# Altium schematic sheet TopLevel.SchDoc
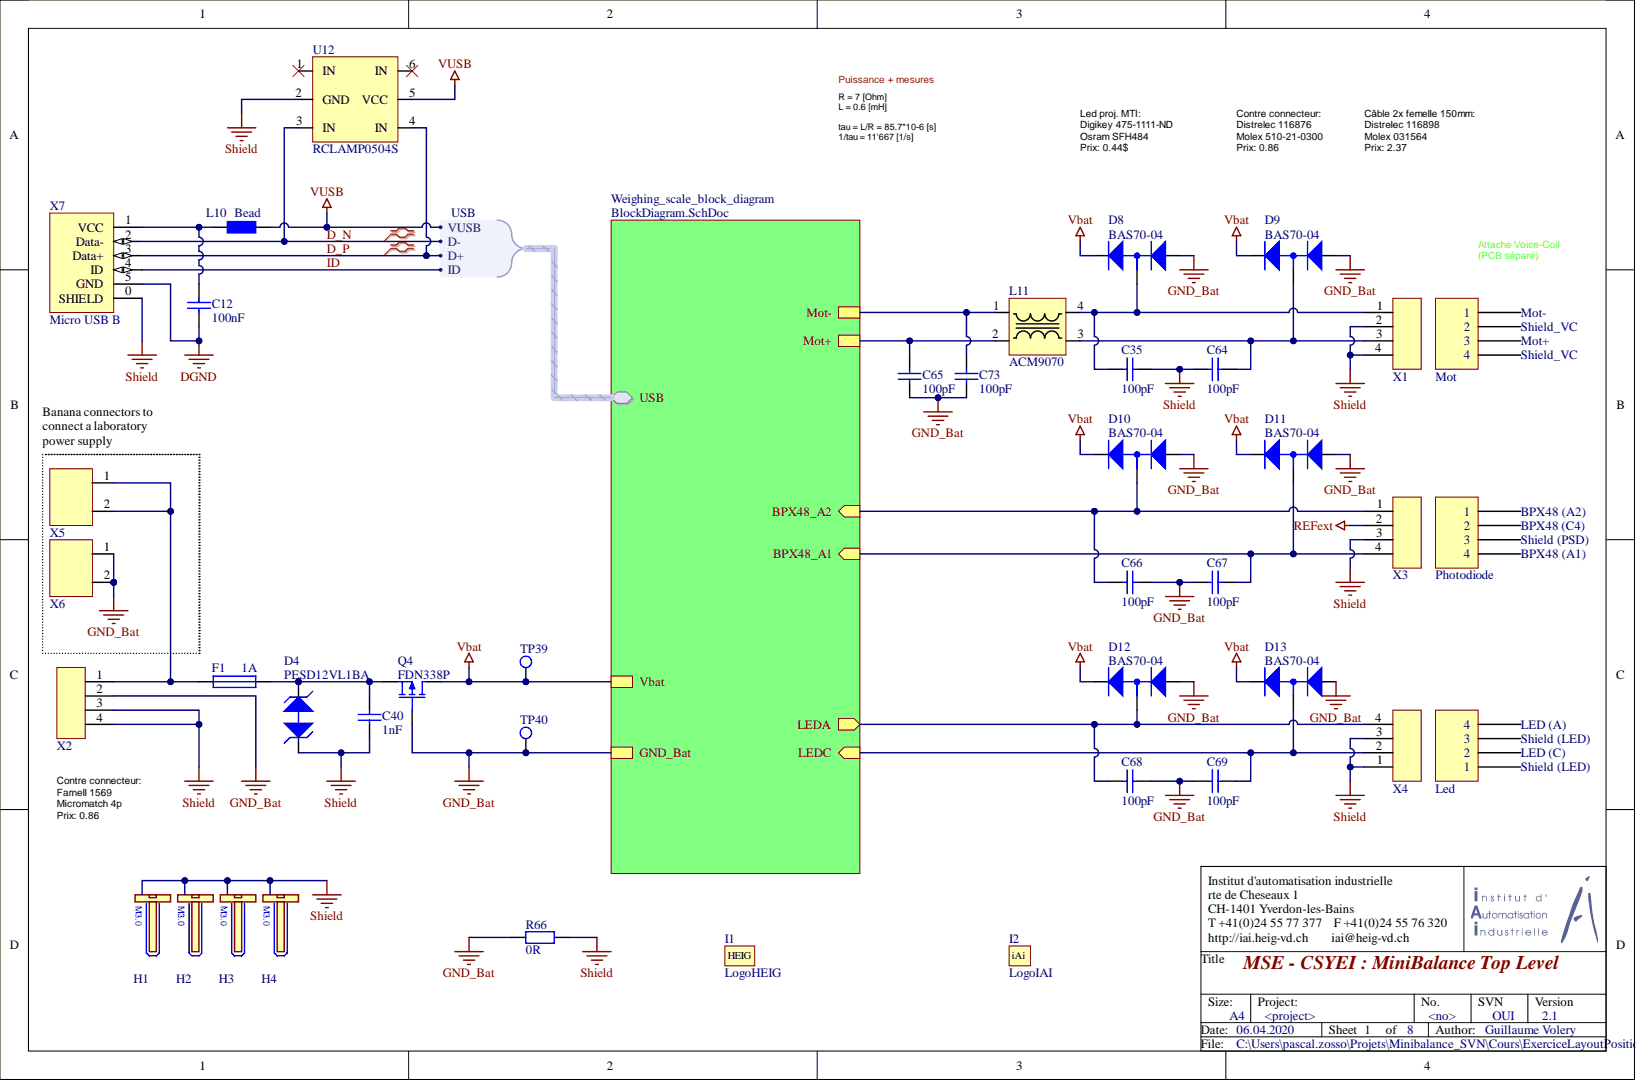
[diagram p0: TopLevel.SchDoc]

## Parts
C12  100nF  [CAPC2012N]
C35  100pF  [CAPC2012N]
C40  1nF  [CAPC2012N]
C64  100pF  [CAPC2012N]
C65  100pF  [CAPC2012N]
C66  100pF  [CAPC2012N]
C67  100pF  [CAPC2012N]
C68  100pF  [CAPC2012N]
C69  100pF  [CAPC2012N]
C73  100pF  [CAPC2012N]
D4  PESD12VL1BA  [SOD323]
D8  BAS70-04  [SOT23-3N]
D9  BAS70-04  [SOT23-3N]
D10  BAS70-04  [SOT23-3N]
D11  BAS70-04  [SOT23-3N]
D12  BAS70-04  [SOT23-3N]
D13  BAS70-04  [SOT23-3N]
F1  1A  [RESC2012N]
H1  Mhole M3  [MHOLE_M3.0]  (value not drawn)
H2  Mhole M3  [MHOLE_M3.0]  (value not drawn)
H3  Mhole M3  [MHOLE_M3.0]  (value not drawn)
H4  Mhole M3  [MHOLE_M3.0]  (value not drawn)
I1  LogoHEIG  [LOGO HEIG-VD_TOP/solder TOP]
I2  LogoIAI  [LOGO_IAI_TOP]
L10  Bead  [RESC2012N]
L11  ACM9070  [ACM9070]
Q4  FDN338P  [SOT23-3N]
R66  0R  [RESC2012N]
TP39  TP  [Testpoint]
TP40  TP  [Testpoint]
U12  RCLAMP0504S  [SOT-23-6]
X1  MicroMatch4p  [MicroMatch4p]  (value not drawn)
X2  MicroMatch4p  [MicroMatch4p]  (value not drawn)
X3  MicroMatch4p  [MicroMatch4p]  (value not drawn)
X4  MicroMatch4p  [MicroMatch4p]  (value not drawn)
X5  Fiche Banane Rouge 4mm  [BANANE]  (value not drawn)
X6  Fiche Banane Noire 4mm  [BANANE]  (value not drawn)
X7  Micro USB B  [Micro_USB_ZX62-B-5PA(33)]

## Nets
DGND: C12.1 X7.5(GND)
GND_Bat: C65.1 C66.2 C67.1 C68.2 C69.1 C73.1 D8.1 D9.1 D10.1 D11.1 D12.1 D13.1 Q4.1 R66.1 TP40.1 X2.2 X6.1 X6.2
REFext: X3.2
Shield: C35.2 C40.1 C64.1 D4.1 H1.1 H2.1 H3.1 H4.1 R66.2 U12.2(GND) X1.2 X1.4 X2.3 X2.4 X3.3 X4.1 X4.3 X7.0(SHIELD)
Vbat: D8.2 D9.2 D10.2 D11.2 D12.2 D13.2 Q4.3 TP39.1
VUSB: L10.2 U12.5(VCC)
D_N: U12.3(IN) X7.2(Data-)
D_P: U12.4(IN) X7.3(Data+)
ID: X7.4(ID)
NetC12_2: C12.2 L10.1 X7.1(VCC)
NetC35_1: C35.1 D8.3 L11.4 X1.1
NetC40_2: C40.2 D4.2 F1.2 Q4.2
NetC64_2: C64.2 D9.3 L11.3 X1.3
NetC65_2: C65.2 L11.2
NetC66_1: C66.1 D10.3 X3.1
NetC67_2: C67.2 D11.3 X3.4
NetC68_1: C68.1 D12.3 X4.4
NetC69_2: C69.2 D13.3 X4.2
NetC73_2: C73.2 L11.1
NetF1_1: F1.1 X2.1 X5.1 X5.2
NetU12_1: U12.1(IN)
NetU12_6: U12.6(IN)

## Library data of the parts
C12 = Cap_100nF: Capacitor; Component Kind: Standard; Supplier 1: Digi-Key; Supplier Part Number 1: 478-1395-1-ND; Value: 100nF; Library Name: Balance.SchLib
C35 C64-C69 C73 = Cap_100pF: Capacitor; Component Kind: Standard; Supplier 1: Digi-Key; Supplier Part Number 1: 399-1122-1-ND; Value: 100pF; Library Name: Balance.SchLib
C40 = Cap_1nF: Capacitor; Component Kind: Standard; Supplier 1: Digi-Key; Supplier Part Number 1: 311-1127-1-ND; Value: 1nF; Library Name: Balance.SchLib
F1 = Fuse_1A: MFU0805FF01000P100; Component Kind: Standard; Supplier 1: Digi-Key; Supplier Part Number 1: MFU08051.00CT-ND; Value: 1A; Library Name: Balance.SchLib
H1-H4 = M3: Component Kind: Standard; Value: Mhole M3; Library Name: Balance.SchLib
Q4 = FDN338P: MOSFet P; Component Kind: Standard; Supplier 1: Digi-Key; Supplier Part Number 1: FDN338PCT-ND; Value: FDN338P; Library Name: Balance.SchLib
R66 = Res_0R: Resistor; Component Kind: Standard; Supplier 1: Digi-Key; Supplier Part Number 1: 311-0.0ARCT-ND; Value: 0R; Library Name: Balance.SchLib
U12 = RCLAMP0504S.TCT: Component Kind: Standard; Supplier 1: Digi-Key; Supplier Part Number 1: RCLAMP0504SCT-ND; Library Name: Balance.SchLib
X7 = Micro_USB_ZX62-B-5PA(33): Component Kind: Standard; Supplier 1: Digi-Key; Supplier Part Number 1: H125270CT-ND; Library Name: Balance.SchLib
D4 = PESD12VL1BA: Component Kind: Standard; Supplier 1: Digi-Key; Supplier Part Number 1: 568-4022-1-ND; Library Name: Balance.SchLib
D8-D13 = BAS70-04: Component Kind: Standard; Supplier 1: Digi-Key; Supplier Part Number 1: BAS70-04-FDICT-ND; Library Name: Balance.SchLib
I1 = LogoHEIG: Component Kind: Standard; Library Name: Balance.SchLib
I2 = LogoIAI: Component Kind: Standard; Library Name: Balance.SchLib
L10 = Bead: Component Kind: Standard; Supplier 1: Digi-Key; Supplier Part Number 1: 240-2399-1-ND; Value: Bead; Library Name: Balance.SchLib
L11 = ACM9070: Component Kind: Standard; Supplier 1: Digi-Key; Supplier Part Number 1: 445-2216-1-ND; Library Name: Balance.SchLib
TP39 TP40 = TP: Component Kind: Standard; Supplier 1: Digi-Key; Supplier Part Number 1: 36-5006-ND; Library Name: Balance.SchLib
X1-X4 = MicroMatch4p: Component Kind: Standard; Supplier 1: Digi-Key; Supplier Part Number 1: A107022CT-ND; Library Name: Balance.SchLib
X5 = Fiche Banane Rouge 4mm: Component Kind: Standard; Supplier 1: Farnell; Supplier Part Number 1: 1698982; Library Name: Balance.SchLib
X6 = Fiche Banane Noire 4mm: Component Kind: Standard; Supplier 1: Farnell; Supplier Part Number 1: 1698983; Library Name: Balance.SchLib
